# Altium schematic sheet POWER.SchDoc(POWER)
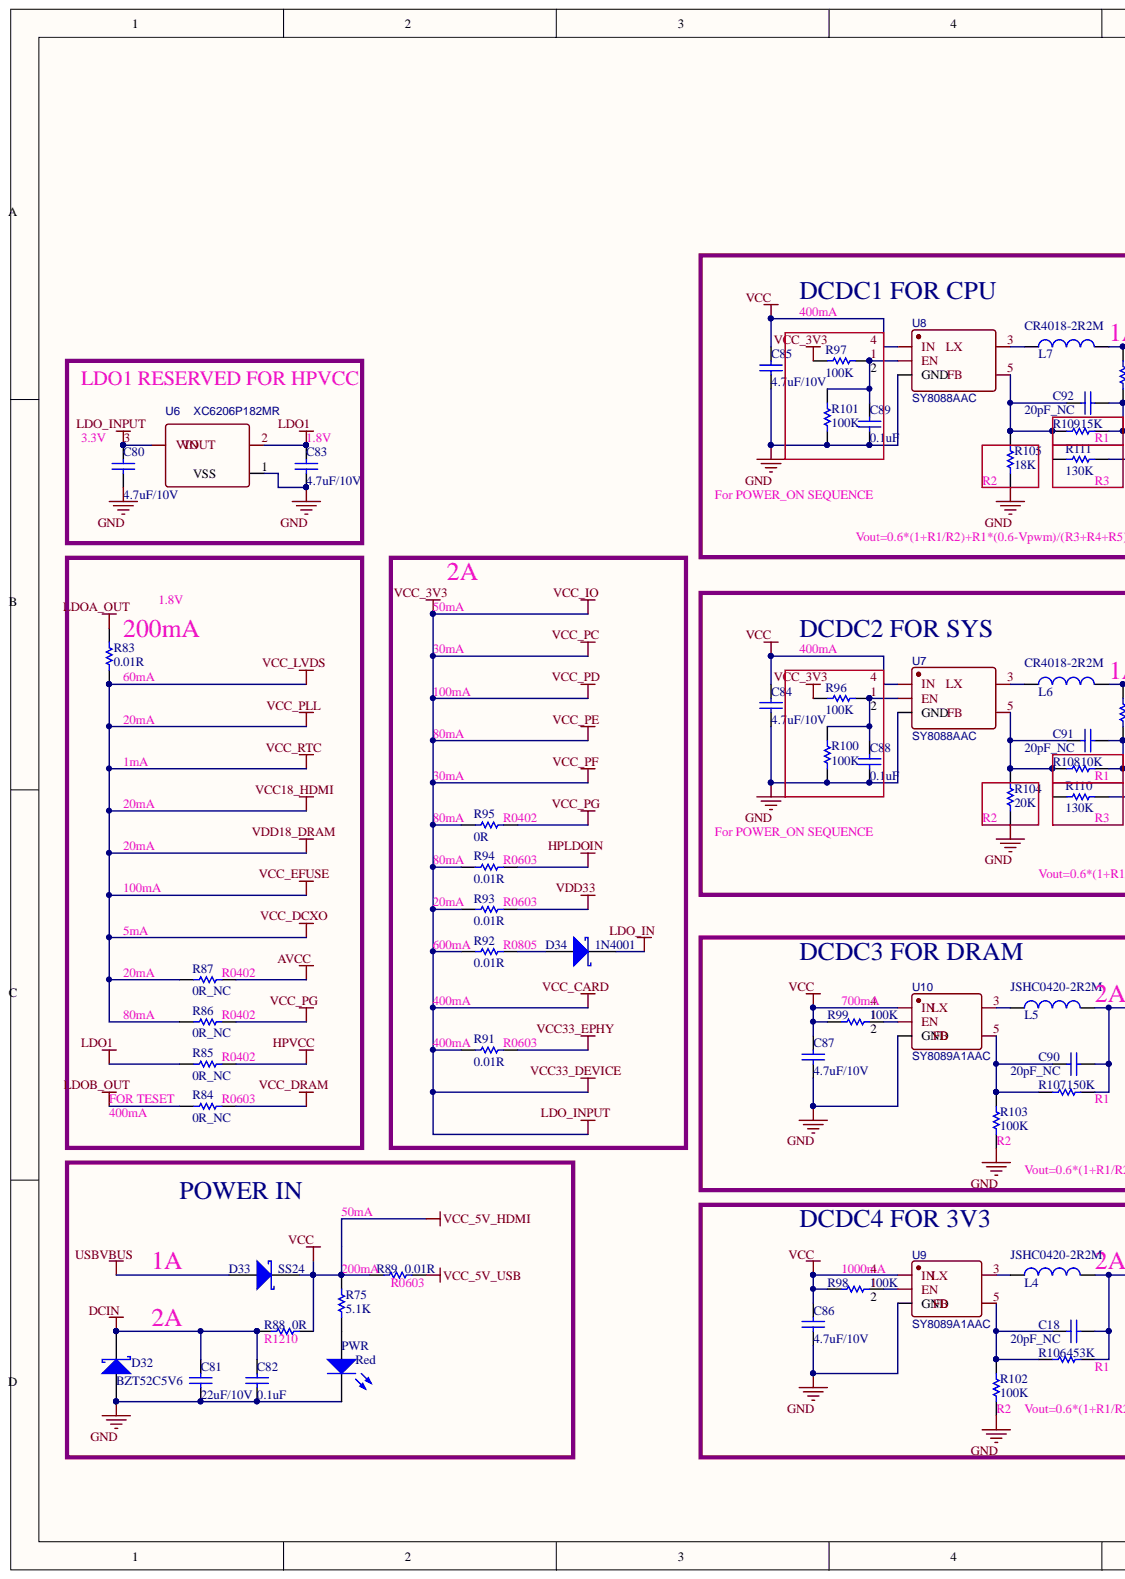
[diagram p0: POWER.SchDoc, left]
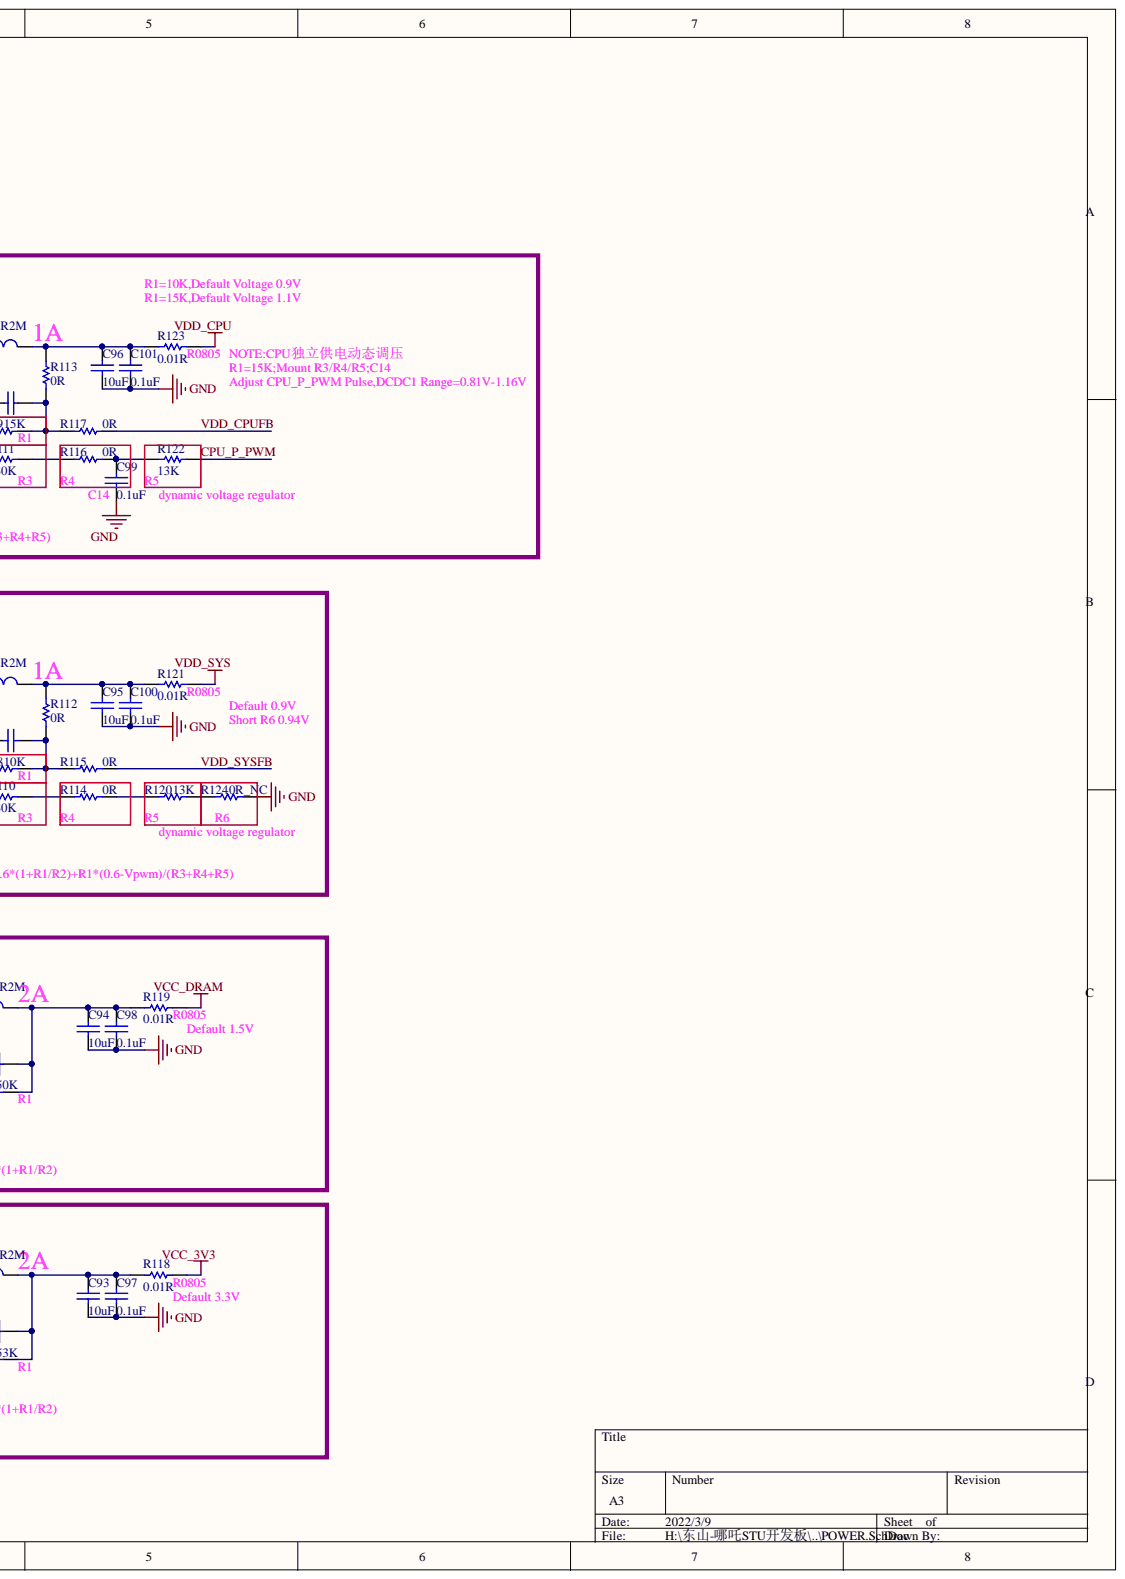
[diagram p1: POWER.SchDoc, right]

## Parts
C18  20pF_NC  [0402]
C80  4.7uF/10V  [0603]
C81  22uF/10V  [0603]
C82  0.1uF  [0402]
C83  4.7uF/10V  [0603]
C84  4.7uF/10V  [0603]
C85  4.7uF/10V  [0603]
C86  4.7uF/10V  [0603]
C87  4.7uF/10V  [0603]
C88  0.1uF  [0402]
C89  0.1uF  [0402]
C90  20pF_NC  [0402]
C91  20pF_NC  [0402]
C92  20pF_NC  [0402]
C93  10uF  [0603]
C94  10uF  [0603]
C95  10uF  [0603]
C96  10uF  [0603]
C97  0.1uF  [0402]
C98  0.1uF  [0402]
C99  0.1uF  [0402]
C100  0.1uF  [0402]
C101  0.1uF  [0402]
D32  BZT52C5V6  [SOD-123_L2.8-W1.8-LS3.7-RD]
D33  SS24  [SMA]
D34  1N4001  [SMA]
L4  JSHC0420-2R2M  [IND-SMD_L4.9-W4.5]
L5  JSHC0420-2R2M  [IND-SMD_L4.9-W4.5]
L6  CR4018-2R2M  [IND-SMD_L4.0-W4.0]
L7  CR4018-2R2M  [IND-SMD_L4.0-W4.0]
PWR  Red  [LED0603]
R75  5.1K  [0402]
R83  0.01R  [0603]
R84  0R_NC  [0603]
R85  0R_NC  [0402]
R86  0R_NC  [0402]
R87  0R_NC  [0402]
R88  0R  [R1210]
R89  0.01R  [0603]
R91  0.01R  [0603]
R92  0.01R  [0805R]
R93  0.01R  [0603]
R94  0.01R  [0603]
R95  0R  [0402]
R96  100K  [0402]
R97  100K  [0402]
R98  100K  [0402]
R99  100K  [0402]
R100  100K  [0402]
R101  100K  [0402]
R102  100K  [0402]
R103  100K  [0402]
R104  20K  [0402]
R105  18K  [0402]
R106  453K  [0402]
R107  150K  [0402]
R108  10K  [0402]
R109  15K  [0402]
R110  130K  [0402]
R111  130K  [0402]
R112  0R  [0402]
R113  0R  [0402]
R114  0R  [0402]
R115  0R  [0402]
R116  0R  [0402]
R117  0R  [0402]
R118  0.01R  [0805R]
R119  0.01R  [0805R]
R120  13K  [0402]
R121  0.01R  [0805R]
R122  13K  [0402]
R123  0.01R  [0805R]
R124  0R_NC  [0402]
U6  XC6206P182MR  [SOT23]
U7  SY8088AAC  [SOT-23-5_L3.0-W1.7-P0.95-LS2.8-BR]
U8  SY8088AAC  [SOT-23-5_L3.0-W1.7-P0.95-LS2.8-BR]
U9  SY8089A1AAC  [SOT-23-5_L3.0-W1.7-P0.95-LS2.8-BL]
U10  SY8089A1AAC  [SOT-23-5_L3.0-W1.7-P0.95-LS2.8-BL]

## Nets
AVCC: R87.1
DCIN: C81.2 C82.1 D32.2 R88.1
GND: C80.2 C81.1 C82.2 C83.2 C84.1 C85.1 C86.1 C87.1 C88.1 C89.1 C93.1 C94.1 C95.1 C96.1 C97.1 C98.1 C99.1 C100.1 C101.1 D32.1 PWR.2 R100.1 R101.1 R102.2 R103.2 R104.2 R105.2 R124.2 U6.1 U7.2(GND) U8.2(GND) U9.2(GND) U10.2(GND)
HPLDOIN: R94.1
HPVCC: R85.1
LDO1: C83.1 R85.2 U6.2
LDO_IN: D34.2
LDO_INPUT: C80.1 R91.2 R92.2 R93.2 R94.2 R95.2 R96.2 R97.2 R118.1 U6.3
LDOA_OUT: R83.2
LDOB_OUT: R84.2
USBVBUS: D33.1
VCC: C84.2 C85.2 C86.2 C87.2 D33.2 R75.2 R88.2 R89.1 R98.2 R99.2 U7.4(IN) U8.4(IN) U9.4(IN) U10.4(IN)
VCC18_HDMI: R83.1 R86.2 R87.2
VCC33_EPHY: R91.1
VCC_5V_USB: R89.2
VCC_DRAM: R84.1 R119.1
VCC_PG: R86.1 R95.1
VDD33: R93.1
VDD_CPU: R123.1
VDD_SYS: R121.1
CPU_P_PWM: R122.1
VDD_CPUFB: R117.1
VDD_SYSFB: R115.1
NetC18_1: C18.1 R102.1 R106.2 U9.5
NetC18_2: C18.2 C93.2 C97.2 L4.2 R106.1 R118.2
NetC88_2: C88.2 R96.1 R100.2 U7.1(EN)
NetC89_2: C89.2 R97.1 R101.2 U8.1(EN)
NetC90_1: C90.1 R103.1 R107.2 U10.5
NetC90_2: C90.2 C94.2 C98.2 L5.2 R107.1 R119.2
NetC91_1: C91.1 R104.1 R108.2 R110.2 U7.5
NetC91_2: C91.2 R108.1 R112.2 R115.2
NetC92_1: C92.1 R105.1 R109.2 R111.2 U8.5
NetC92_2: C92.2 R109.1 R113.2 R117.2
NetC95_2: C95.2 C100.2 L6.2 R112.1 R121.2
NetC96_2: C96.2 C101.2 L7.2 R113.1 R123.2
NetC99_2: C99.2 R116.1 R122.2
NetD34_1: D34.1 R92.1
NetL4_1: L4.1 U9.3
NetL5_1: L5.1 U10.3
NetL6_1: L6.1 U7.3
NetL7_1: L7.1 U8.3
NetPWR_1: PWR.1 R75.1
NetR98_1: R98.1 U9.1(EN)
NetR99_1: R99.1 U10.1(EN)
NetR110_1: R110.1 R114.2
NetR111_1: R111.1 R116.2
NetR114_1: R114.1 R120.2
NetR120_1: R120.1 R124.1

## Library data of the parts
C18 C80-C101 = Cap: Capacitor; PartNumber: CAP100NF; Component Kind: Standard; LatestRevisionDate: 17-Jul-2002; LatestRevisionNote: Re-released for DXP Platform.; Library Name: Miscellaneous Devices.IntLib; PackageReference: 0402; Published: 8-Jun-2000; Signal Integrity: Cap; Simulation: CAP; Publisher: Altium Limited
  C18 C90-C92: Value: 20pF_NC
  C80 C83-C87: Value: 4.7uF/10V
  C81: Value: 22uF/10V
  C82 C88 C89 C97-C101: Value: 0.1uF
  C93-C96: Value: 10uF
D32-D34 = D Schottky: Schottky Diode; Code_JEDEC: DO-214-AA; Component Kind: Standard; LatestRevisionDate: 17-Jul-2002; LatestRevisionNote: Re-released for DXP Platform.; Library Name: Miscellaneous Devices.IntLib; PackageDescription: DO-214-AA/SMB; 2 C-Bend Leads; Body 5.3 x 3.6 mm, inc. leads (LxW); PackageReference: SMB; Published: 8-Jun-2000; Signal Integrity: D Schottky; Simulation: SKYDIODE; Publisher: Altium Limited
  D32: Value: BZT52C5V6
  D33: Value: SS24
  D34: Value: 1N4001
L4-L7 = Inductor: PartNumber: IND10UH; Code_IEC: 1005; Code_JEITA: 0402; Component Kind: Standard; Description: Inductor; LatestRevisionDate: 29-May-2009; LatestRevisionNote: IPC-7351 Footprint Added.; Library Name: Miscellaneous Devices.IntLib; PackageDescription: Chip Inductor; PackageReference: 0402-A; Published: 8-Jun-2000; Signal Integrity: Inductor; Simulation: INDUCTOR; Publisher: Altium Limited
  L4 L5: JLC NO: C386778; Value: JSHC0420-2R2M
  L6 L7: JLC NO: C520302; Value: CR4018-2R2M
PWR = LED2: Typical RED, GREEN, YELLOW, AMBER GaAs LED; Component Kind: Standard; LatestRevisionDate: 17-Jul-2002; LatestRevisionNote: Re-released for DXP Platform.; Library Name: Miscellaneous Devices.IntLib; PackageDescription: SM LED; 2 Flat Leads; PackageReference: 3.2X1.6X1.1; PackageVersion: Feb-2002; Published: 8-Jun-2000; Simulation: LED2; Value: Red; Publisher: Altium Limited
R75 R83-R89 R91-R124 = Res1: Resistor; PartNumber: RES2.2K; Component Kind: Standard; LatestRevisionDate: 17-Jul-2002; LatestRevisionNote: Re-released for DXP Platform.; Library Name: Miscellaneous Devices.IntLib; PackageReference: 0402; Published: 8-Jun-2000; Signal Integrity: Res; Simulation: RESISTOR; Publisher: Altium Limited
  R75: Value: 5.1K
  R83 R89 R91-R94 R118 R119 R121 R123: Value: 0.01R
  R84-R87 R124: Value: 0R_NC
  R88 R95 R112-R117: Value: 0R
  R96-R103: Value: 100K
  R104: Value: 20K
  R105: Value: 18K
  R106: Value: 453K
  R107: Value: 150K
  R108: Value: 10K
  R109: Value: 15K
  R110 R111: Value: 130K
  R120 R122: Value: 13K
U6 = XC6206P182MR_C2904834: Contributor: LCSC; BOM_Manufacturer Part: XC6206P182MR; BOM_Supplier: LCSC; BOM_Supplier Part: C2904834; Component Kind: Standard; Library Name: HM2.SchLib; spicePre: U; spiceSymbolName: XC6206P182MR_C2904834
U7 U8 = SY8088AAC: BOM_Manufacturer: Silergy; BOM_JLCPCB Part Class: Extended Part; BOM_Manufacturer Part: SY8088AAC; BOM_Supplier: LCSC; BOM_Supplier Part: C79313; Component Kind: Standard; Library Name: HM2.SchLib; spicePre: U; spiceSymbolName: SY8088AAC
U9 U10 = SY8089A1AAC: BOM_Manufacturer: Silergy Corp; Contributor: LCSC; BOM_JLCPCB Part Class: Extended Part; BOM_Manufacturer Part: SY8089A1AAC; BOM_Supplier: LCSC; BOM_Supplier Part: C479074; Component Kind: Standard; Library Name: HM2.SchLib; spicePre: U; spiceSymbolName: SY8089A1AAC
